# Altium schematic sheet SCH_System.SchDoc(SCH_System)
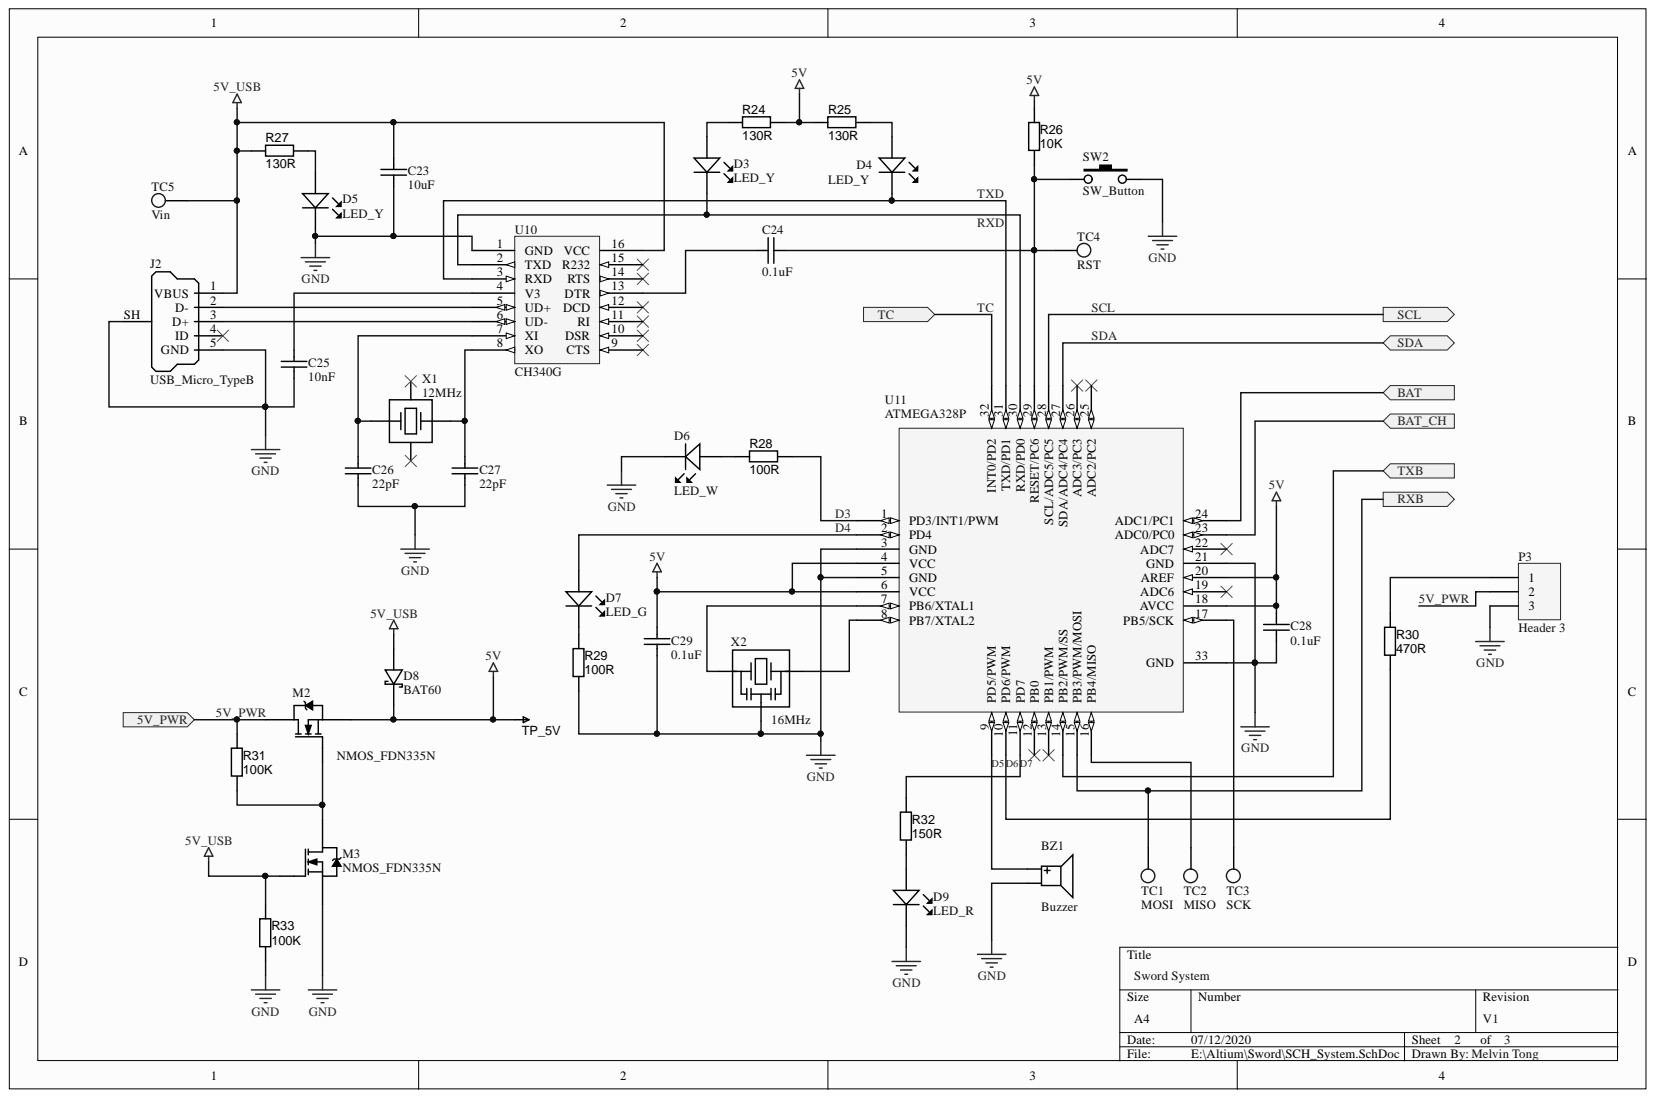
[diagram p0: SCH_System.SchDoc]

## Parts
BZ1  Buzzer  [Buzzer]
C23  10uF  [CAP_1206]
C24  0.1uF  [CAP_0805]
C25  10nF  [CAP_0805]
C26  22pF  [CAP_0805]
C27  22pF  [CAP_0805]
C28  0.1uF  [CAP_0805]
C29  0.1uF  [CAP_0805]
D3  LED_Y  [LED-0603-YELLOW]
D4  LED_Y  [LED-0603-YELLOW]
D5  LED_Y  [LED-0603-YELLOW]
D6  LED_W  [LED_0805]
D7  LED_G  [LED_0805]
D8  BAT60  [SOD323]
D9  LED_R  [LED_0805]
J2  USB_Micro_TypeB  [USB_Micro_TypeB]
M2  NMOS_FDN335N  [SOT23_213]
M3  NMOS_FDN335N  [SOT23_213]
P3  Header 3  [HDR1X3]
R24  130R  [RESC3216X70N]
R25  130R  [RESC3216X70N]
R26  10K  [RESC3216X70N]
R27  130R  [RESC3216X70N]
R28  100R  [RESC3216X70N]
R29  100R  [RESC3216X70N]
R30  470R  [RESC3216X70N]
R31  100K  [RESC3216X70N]
R32  150R  [RESC3216X70N]
R33  100K  [RESC3216X70N]
SW2  SW_Button  [Button_4x4x1.5]
TC1  MOSI  [TP_15]
TC2  MISO  [TP_15]
TC3  SCK  [TP_15]
TC4  RST  [TP_15]
TC5  Vin  [TP_15]
TP_5V  TESTPOINT  [TP-R20]  (value not drawn)  (no BOM)
U10  CH340G  [CH340G]
U11  ATMEGA328P  [ATMEGA328P-MU_32M1-A]
X1  12MHz  [XTAL_12MHz]
X2  16MHz  [XTAL_16MHz]

## Ports
5V_PWR, BAT, BAT_CH, RXB, SCL, SDA, TC, TXB

## Nets
5V: C28.2 C29.2 D8.K M2.2 R24.2 R25.1 R26.1 TP_5V.1 U11.4(VCC) U11.6(VCC) U11.18(AVCC) U11.20(AREF)
5V_USB: C23.1 D8.A J2.1(VBUS) M3.1 R27.1 R33.1 TC5.1 U10.16(VCC)
GND: BZ1.2 C23.2 C25.2 C26.2 C27.2 C28.1 C29.1 D5.K D6.K D9.K J2.5(GND) J2.SH M3.2 P3.3 R29.1 R33.2 SW2.2 U10.1(GND) U11.3(GND) U11.5(GND) U11.21(GND) U11.33(GND) X2.2
5V_PWR: M2.3 P3.2 R31.1 ; port 5V_PWR
BAT: U11.24(ADC1/PC1) ; port BAT
BAT_CH: U11.23(ADC0/PC0) ; port BAT_CH
D3: R28.2 U11.1(PD3/INT1/PWM)
D4: D7.A U11.2(PD4)
D5: BZ1.1 U11.9(PD5/PWM)
D6: R30.2 U11.10(PD6/PWM)
D7: R32.1 U11.11(PD7)
RXB: TC1.1 U11.15(PB3/PWM/MOSI) ; port RXB
RXD: D3.K U10.2(TXD) U11.30(RXD/PD0)
SCL: U11.28(SCL/ADC5/PC5) ; port SCL
SDA: U11.27(SDA/ADC4/PC4) ; port SDA
TC: U11.32(INT0/PD2) ; port TC
TXB: U11.14(PB2/PWM/SS) ; port TXB
TXD: D4.K U10.3(RXD) U11.31(TXD/PD1)
NetC24_1: C24.1 U10.13(DTR)
NetC24_2: C24.2 R26.2 SW2.1 TC4.1 U11.29(RESET/PC6)
NetC25_1: C25.1 U10.4(V3)
NetC26_1: C26.1 U10.7(XI) X1.1
NetC27_1: C27.1 U10.8(XO) X1.3
NetD3_A: D3.A R24.1
NetD4_A: D4.A R25.2
NetD5_A: D5.A R27.2
NetD6_A: D6.A R28.1
NetD7_K: D7.K R29.2
NetD9_A: D9.A R32.2
NetJ2_2: J2.2(D-) U10.5(UD+)
NetJ2_3: J2.3(D+) U10.6(UD-)
NetM2_1: M2.1 M3.3 R31.2
NetP3_1: P3.1 R30.1
NetTC2_1: TC2.1 U11.16(PB4/MISO)
NetTC3_1: TC3.1 U11.17(PB5/SCK)
NetU11_7: U11.7(PB6/XTAL1) X2.1
NetU11_8: U11.8(PB7/XTAL2) X2.3

Not connected: J2 4(ID); U10 9(CTS) 10(DSR) 11(RI) 12(DCD) 14(RTS) 15(R232); U11 12(PB0) 13(PB1/PWM) 19(ADC6) 22(ADC7) 25(ADC2/PC2) 26(ADC3/PC3); X1 2 4

## Library data of the parts
BZ1 = Buzzer: Buzzer passive 5 VAC; Component Kind: Standard; Library Name: Schlib_Sword.SchLib
C23 = CAP_10uF: SMD 0805/1206; Component Kind: Standard; Library Name: Schlib_Sword.SchLib
C24 C28 C29 = CAP_0.1uF: SMD 0805; Component Kind: Standard; Library Name: Schlib_Sword.SchLib
C25 = CAP_10nF: SMD 0805; Component Kind: Standard; Library Name: Schlib_Sword.SchLib
C26 C27 = CAP_22pF: SMD 0805; Component Kind: Standard; Library Name: Schlib_Sword.SchLib
D3-D5 = LED_Y: SMD_0603 2.4V; Component Kind: Standard; Library Name: Schlib_Sword.SchLib
D6 = LED_W: SMD_0805 3V; Component Kind: Standard; Library Name: Schlib_Sword.SchLib
D7 = LED_G: SMD_0805 3V; Component Kind: Standard; Library Name: Schlib_Sword.SchLib
D8 = BAT60: Schottky Diode 3A, Vf~0.24V, Vr=10V; Component Kind: Standard; Library Name: Schlib_Sword.SchLib
D9 = LED_R: SMD_0805 2V; Component Kind: Standard; Library Name: Schlib_Sword.SchLib
P3 = Header 3: Header, 3-Pin; Signal Integrity: Connector; Library Name: Miscellaneous Connectors.IntLib; LatestRevisionNote: Re-released for DXP Platform.; LatestRevisionDate: 17-Jul-2002; Component Kind: Standard; Publisher: Altium Limited
R24 R25 R27 = RES_130R: Resistor SMD, 1206; Value: N/A; Component Kind: Standard; Library Name: Schlib_Sword.SchLib
R26 = RES_10K: Resistor SMD, 1206; Value: N/A; Component Kind: Standard; Library Name: Schlib_Sword.SchLib
R28 R29 = RES_100R: Resistor SMD, 1206; Value: N/A; Component Kind: Standard; Library Name: Schlib_Sword.SchLib
R30 = RES_470R: Resistor SMD, 1206; Value: N/A; Component Kind: Standard; Library Name: Schlib_Sword.SchLib
R31 R33 = RES_100K: Resistor SMD, 1206; Value: N/A; Component Kind: Standard; Library Name: Schlib_Sword.SchLib
R32 = RES_150R: Resistor SMD, 1206; Value: N/A; Component Kind: Standard; Library Name: Schlib_Sword.SchLib
TC1-TC5 = TESTCONN: Component Kind: Standard; Library Name: Schlib_Sword.SchLib
TP_5V = TESTPOINT: SMD test pin; Library Name: Schlib_Sword.SchLib
X1 = 12MHz: Crystal oscillator; Component Kind: Standard; Library Name: Schlib_Sword.SchLib
X2 = 16MHz: Crystal Oscillator; Component Kind: Standard; Library Name: Schlib_Sword.SchLib
J2 = USB_Micro_TypeB: Component Kind: Standard; Library Name: Schlib_Sword.SchLib
M2 M3 = NMOS_FDN335N: Component Kind: Standard; Library Name: Schlib_Sword.SchLib
SW2 = SW_Button: Component Kind: Standard; Library Name: Schlib_Sword.SchLib
U10 = CH340G: Component Kind: Standard; Library Name: Schlib_Sword.SchLib
U11 = ATMEGA328P: Component Kind: Standard; Library Name: Schlib_Sword.SchLib
